# Altium schematic sheet ClockDisplay.SchDoc(ClockDisplay)
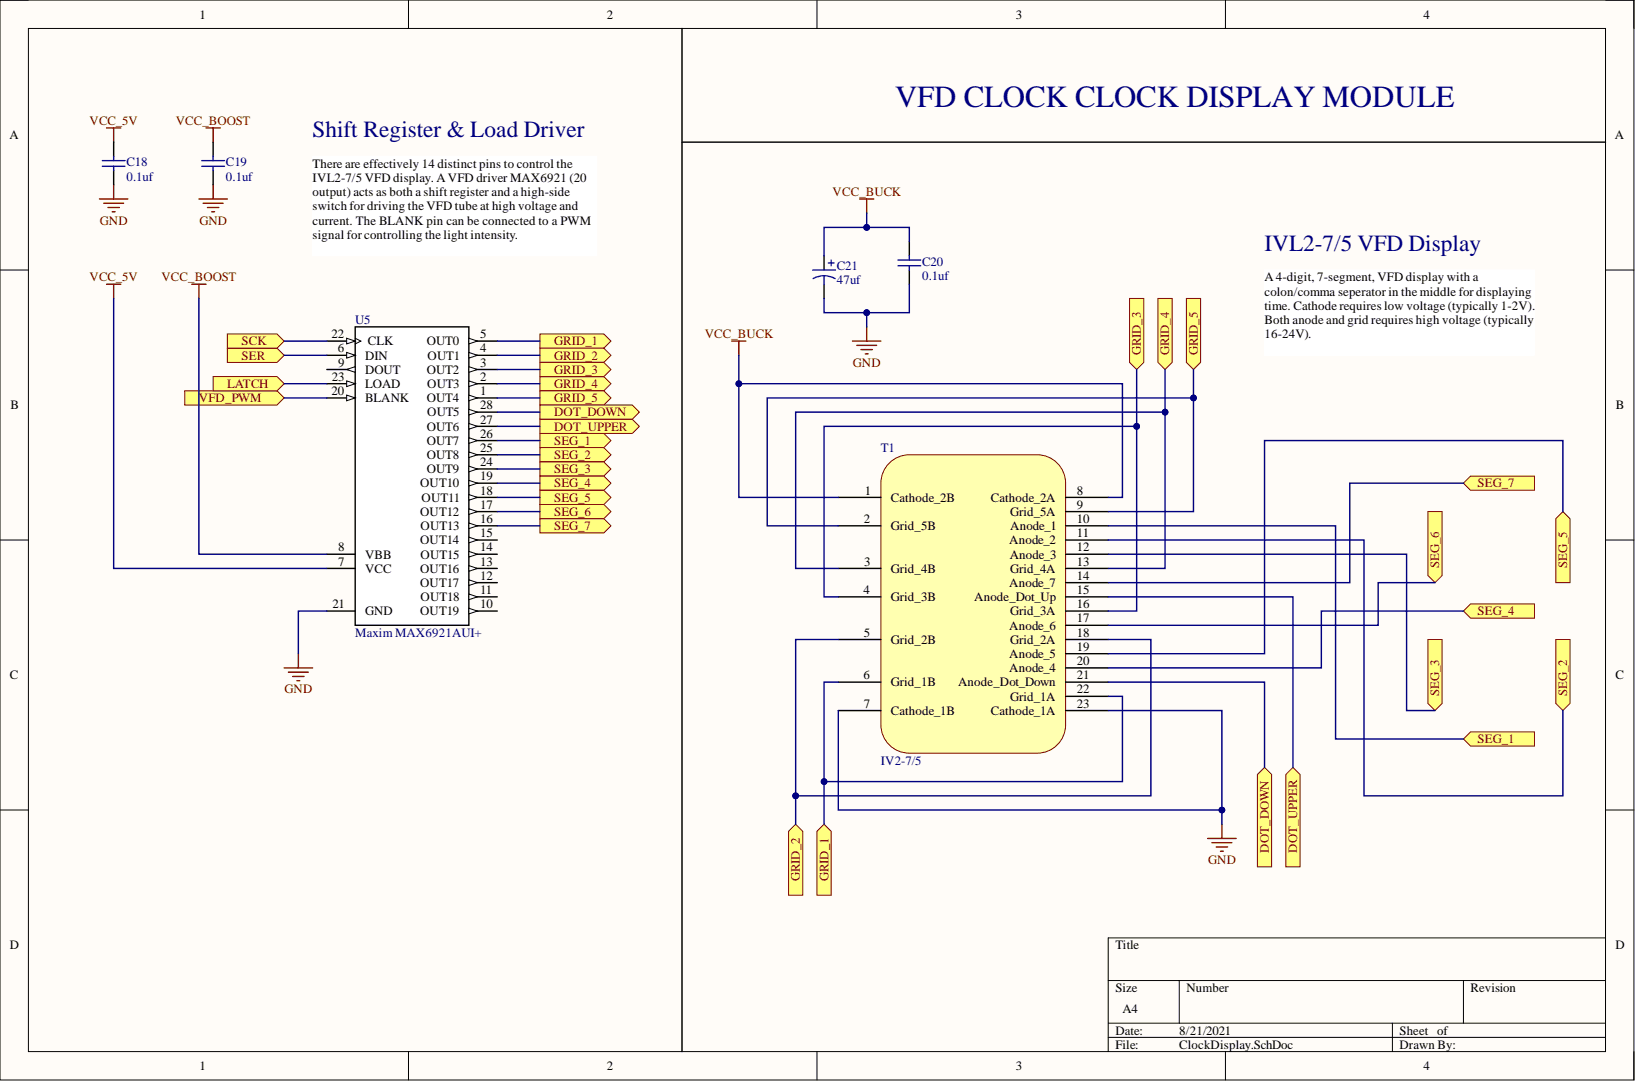
[diagram p0: ClockDisplay.SchDoc]

## Parts
C18  0.1uf  [PCB-xrh88671zmf2jss4mnlt-1]
C19  0.1uf  [PCB-xrh88671zmf2jss4mnlt-1]
C20  0.1uf  [PCB-xrh88671zmf2jss4mnlt-1]
C21  47uf  [PCB-uq8d9yq4jmh6jcskxxgg-1]
T1  IV2-7/5  [IV2-7_5-Footprint-1]
U5  Maxim MAX6921AUI+  Manufacturer Part Number: MAX6921AUI+  [PCB-1422734-1]

## Ports
DOT_DOWN, DOT_UPPER, GRID_1, GRID_2, GRID_3, GRID_4, GRID_5, LATCH, SCK, SEG_1, SEG_2, SEG_3, SEG_4, SEG_5, SEG_6, SEG_7, SER, VFD_PWM

## Nets
GND: C18.1 C19.1 C20.1 C21.2 T1.7(Cathode_1B) T1.23(Cathode_1A) U5.21(GND)
VCC_5V: C18.2 U5.7(VCC)
VCC_BOOST: C19.2 U5.8(VBB)
VCC_BUCK: C20.2 C21.1 T1.1(Cathode_2B) T1.8(Cathode_2A)
DOT_DOWN: T1.21(Anode_Dot_Down) U5.28(OUT5) ; port DOT_DOWN
DOT_UPPER: T1.15(Anode_Dot_Up) U5.27(OUT6) ; port DOT_UPPER
GRID_1: T1.6(Grid_1B) T1.22(Grid_1A) U5.5(OUT0) ; port GRID_1
GRID_2: T1.5(Grid_2B) T1.18(Grid_2A) U5.4(OUT1) ; port GRID_2
GRID_3: T1.4(Grid_3B) T1.16(Grid_3A) U5.3(OUT2) ; port GRID_3
GRID_4: T1.3(Grid_4B) T1.13(Grid_4A) U5.2(OUT3) ; port GRID_4
GRID_5: T1.2(Grid_5B) T1.9(Grid_5A) U5.1(OUT4) ; port GRID_5
LATCH: U5.23(LOAD) ; port LATCH
SCK: U5.22(CLK) ; port SCK
SEG_1: T1.10(Anode_1) U5.26(OUT7) ; port SEG_1
SEG_2: T1.11(Anode_2) U5.25(OUT8) ; port SEG_2
SEG_3: T1.12(Anode_3) U5.24(OUT9) ; port SEG_3
SEG_4: T1.20(Anode_4) U5.19(OUT10) ; port SEG_4
SEG_5: T1.19(Anode_5) U5.18(OUT11) ; port SEG_5
SEG_6: T1.17(Anode_6) U5.17(OUT12) ; port SEG_6
SEG_7: T1.14(Anode_7) U5.16(OUT13) ; port SEG_7
SER: U5.6(DIN) ; port SER
VFD_PWM: U5.20(BLANK) ; port VFD_PWM

Not connected: U5 9(DOUT) 10(OUT19) 11(OUT18) 12(OUT17) 13(OUT16) 14(OUT15) 15(OUT14)

## Library data of the parts
C18-C20 = CMP-7104bbe86e53aec3-2: Capacitor [Würth Elektronik] 885012207051 Capacitor; Capacitance: 1.00 µF; Capacitance Tolerance: ±10%; Dielectric Characteristic: X7R; Voltage Rating (DC): 16.0 V; Component Kind: Standard; Library Name: My Personal Space; Manufacturer Part Number: 885012207051; Octopart URL: https://octopart.com/885012207051-w%C3%BCrth+elektronik-65419825; OctopartID: 7104bbe86e53aec3; Packaging: Cut Tape (CT); RoHS: Compliant
C21 = CMP-7be9b1b305f9a42e-4: Panasonic EEE-1AA470WR  Capacitor; Alum Electrolytic; Cap 47 uF; Tol 20%; Vol-Rtg 10 VDC; SMT; 5x5.5; Cut Tape; Capacitance: 47.0 µF; Lead-Free Status: Lead Free; Voltage Rating (DC): 10.0 V; Capacitance Tolerance: ±20%; Component Kind: Standard; Library Name: My Personal Space; Lifecycle Status: Not Listed by Manufacturer; Manufacturer Part Number: EEE-1AA470WR; Mounting Style: Surface Mount; Octopart URL: http://octopart.com/eee-1aa470wr-panasonic-55597942; OctopartID: 7be9b1b305f9a42e; Packaging: Cut Tape (CT), Tape & Reel (TR); REACH SVHC Compliance: No SVHC; RoHS: Compliant; Size-Diameter: 5.00 mm; Size-Height: 5.40 mm
T1 = CMP-sml2cx31asn5o7aha4gm-6: Small VFD display with full time display capabilities.; Component Kind: Standard; CustomComponentID: sml2cx31asn5o7aha4gm; Library Name: My Personal Space; Part Number: IV2-7/5
U5 = CMP-1422734-2: IC DRVR VFD TUBE SRL 28-TSSOP; Lead-Free Status: Lead Free; Case/Package: TSSOP; Component Kind: Standard; Library Name: My Personal Space; Lifecycle Status: Not Listed by Manufacturer; Number of Pins: 28; Octopart Url: https://octopart.com/max6921aui%2B-maxim+integrated-39847051; OctopartID: c5800eeae46d1a47; Packaging: Tube; RoHS: Compliant
